# Altium schematic sheet EIT_FTDI_Option.SchDoc
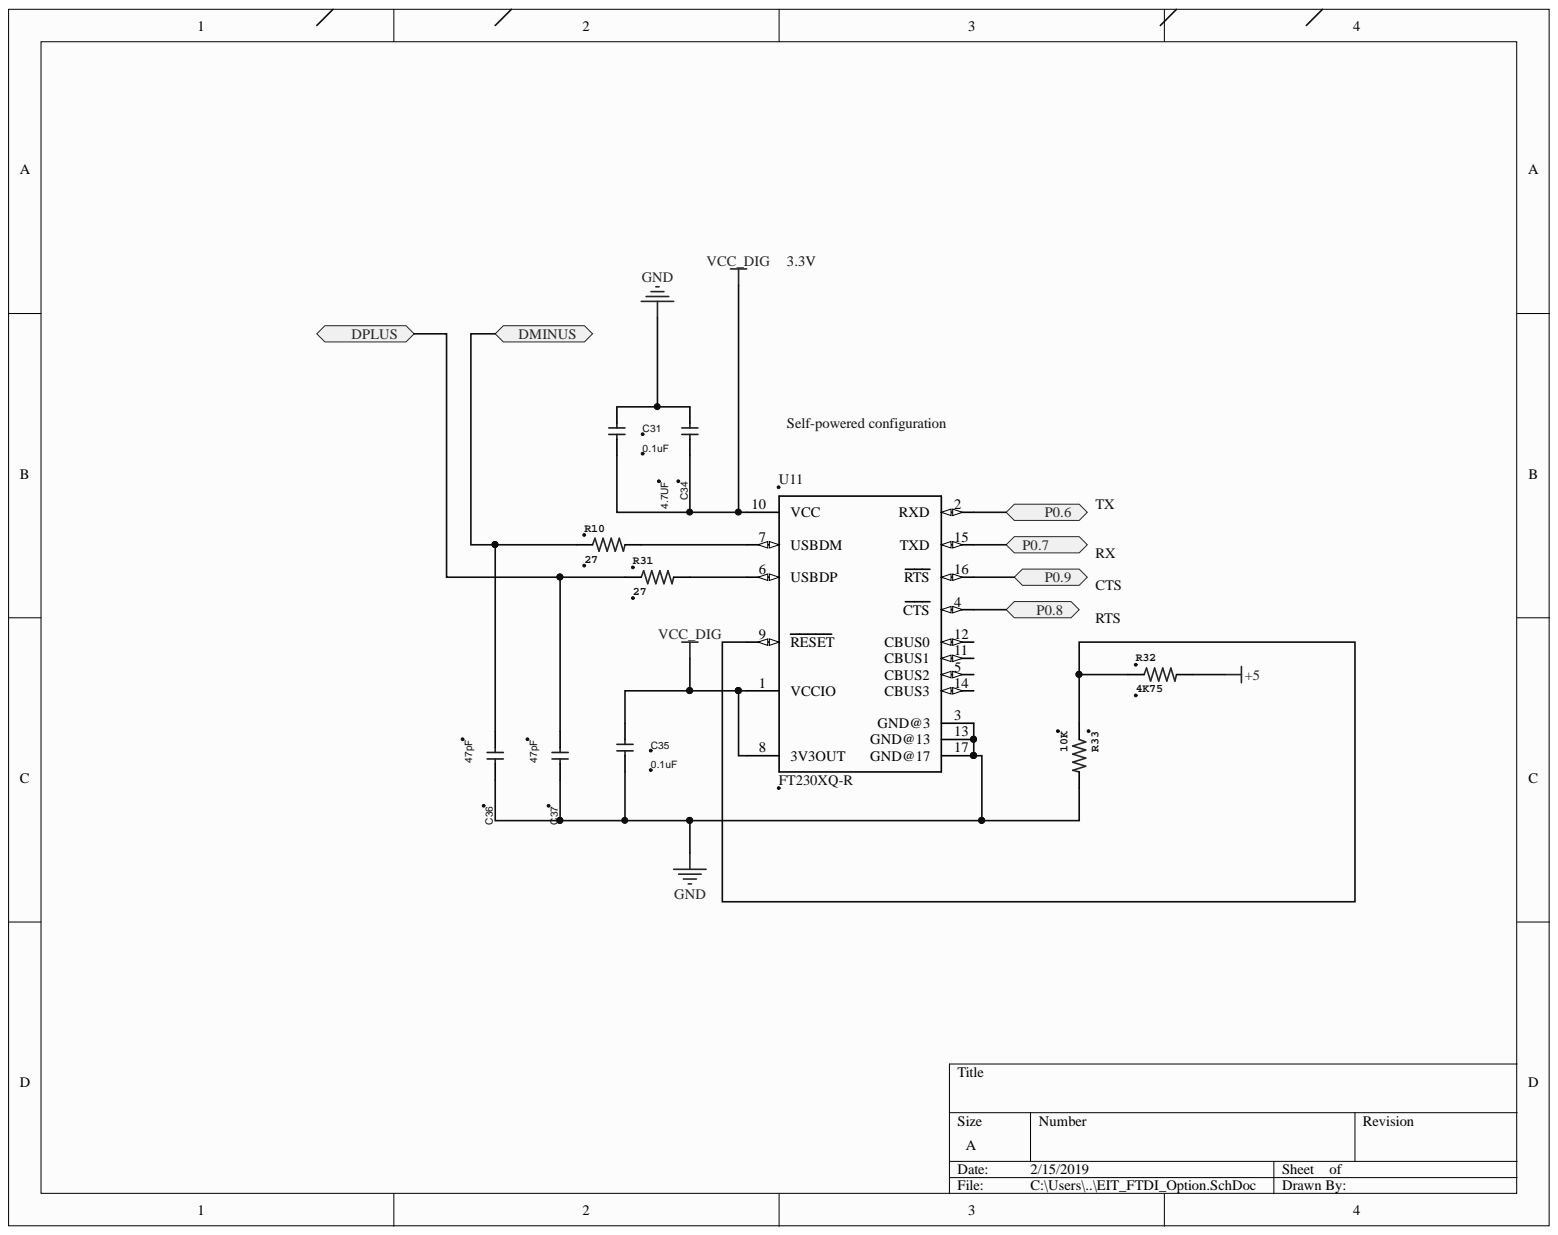
[diagram p0: EIT_FTDI_Option.SchDoc]

## Parts
C31  0.1uF  [CAP_0603]
C34  4.7UF  [CAP 0402/1005]
C35  0.1uF  [CAP_0603]
C36  47pF  [CAP_0603]
C37  47pF  [CAP_0603]
R10  27  [RES_1608X55]
R31  27  [RES_1608X55]
R32  4K75  [RES_1608X55]
R33  10K  [RES_1608X55]
U11  FT230XQ-R  [QFN16]

## Ports
DMINUS, DPLUS, P0.6, P0.7, P0.8, P0.9

## Nets
+5: R32.1
GND: C31.2 C34.2 C35.1 C36.1 C37.1 R33.1 U11.3(GND@3) U11.13(GND@13) U11.17(GND@17)
VCC_DIG: C31.1 C34.1 C35.2 U11.1(VCCIO) U11.8(3V3OUT) U11.10(VCC)
DMINUS: C36.2 R10.2 ; port DMINUS
DPLUS: C37.2 R31.2 ; port DPLUS
P0.6: U11.2(RXD) ; port P0.6
P0.7: U11.15(TXD) ; port P0.7
P0.8: U11.4(CTS) ; port P0.8
P0.9: U11.16(RTS) ; port P0.9
NetR10_1: R10.1 U11.7(USBDM)
NetR31_1: R31.1 U11.6(USBDP)
NetR32_2: R32.2 R33.2 U11.9(RESET)

Not connected: U11 5(CBUS2) 11(CBUS1) 12(CBUS0) 14(CBUS3)

## Library data of the parts
C31 C35 = CAP_0603_0.1uF: CAP CER 0.1UF 6.3V 20% X5R 0603; Manufacturer 1: AVX Corporation; Manufacturer Part Number 1: 06036D104KAT2A; Vendor: DIGIKEY; VendorPN: 399-1095-1-ND; Component Kind: Standard; Supplier 1: Digi-Key; Value: 0.1uF; Library Name: EIT_lib.IntLib
C34 = CAP_0402_4.7UF: CAP CER 4.7UF 4V X5R 0402; Manufacturer 1: Taiyo Yuden; Manufacturer Part Number 1: GRM155R60G475ME87D; Vendor: DIGIKEY; Component Kind: Standard; Supplier 1: Digi-Key; Supplier Part Number 1: 587-1966-6-ND; Value: 4.7UF; Library Name: EIT_lib.SchLib
C36 C37 = CAP_0603_47pF: CAP CER 47PF 100V NPO 0603; Manufacturer 1: YAGEO AMERICA; Manufacturer Part Number 1: CC0603FRNPO0BN470; Vendor: DIGIKEY; Component Kind: Standard; Supplier 1: Digi-Key; Value: 47pF; Library Name: EIT_lib.SchLib
R10 R31 = RES_0603_27: 27 Ohm RES_0603; Manufacturer 1: Rohm Semiconductor; Manufacturer Part Number 1: ESR03EZPJ270; DeviceName: -0603(1608METRIC); DeviceSetName: RESISTOR-US; GateName_1: G$1; Component Kind: Standard; Supplier 1: Digi-Key; SymbolName_1: RESISTOR-US; Value: 27; Library Name: EIT_lib.IntLib; LibraryName: BiomedicalImagingLibrary
R32 = RES_0603_4K75: 4k75Ohm RES_0603; Manufacturer 1: Yageo; Manufacturer Part Number 1: AC0603FR-074K75L; DeviceName: -0603(1608METRIC); DeviceSetName: RESISTOR-US; GateName_1: G$1; Component Kind: Standard; SymbolName_1: RESISTOR-US; Value: 4K75; Library Name: EIT_lib.IntLib
R33 = RES_0603_10K: 10kOhm RES_0603; Manufacturer 1: VISHAY/DALE; Manufacturer Part Number 1: TNPW060310K0BEEA; PARTNO: MCR03ERTF5600; DeviceName: -0603(1608METRIC); DeviceSetName: RESISTOR-US; GateName_1: G$1; Component Kind: Standard; OC_NEWARK: 52K8621; Supplier 1: Digi-Key; Supplier Part Number 1: TNP10.0KAACT-ND; SymbolName_1: RESISTOR-US; Value: 10K; Library Name: EIT_lib.IntLib; LibraryName: BiomedicalImagingLibrary
U11 = FT230XQ-R: FT230X Series 3 Mbaud USB Full Speed to Basic UART IC with USB Charger Detection; Manufacturer 1: FTDI,; Availability: Unavailable; Component Kind: Standard; Manufacturer Part Number 1: FT230XQ-R; Package: QFN-16 FTDI; Price: None; SnapEDA_Link: https://www.snapeda.com/parts/FT230XQ-R/FTDI%2C%20Future%20Technology%20Devices%20International%20Ltd/view-part/?ref=snap; Library Name: EIT_lib.IntLib
